# Altium schematic sheet MIDI.SchDoc
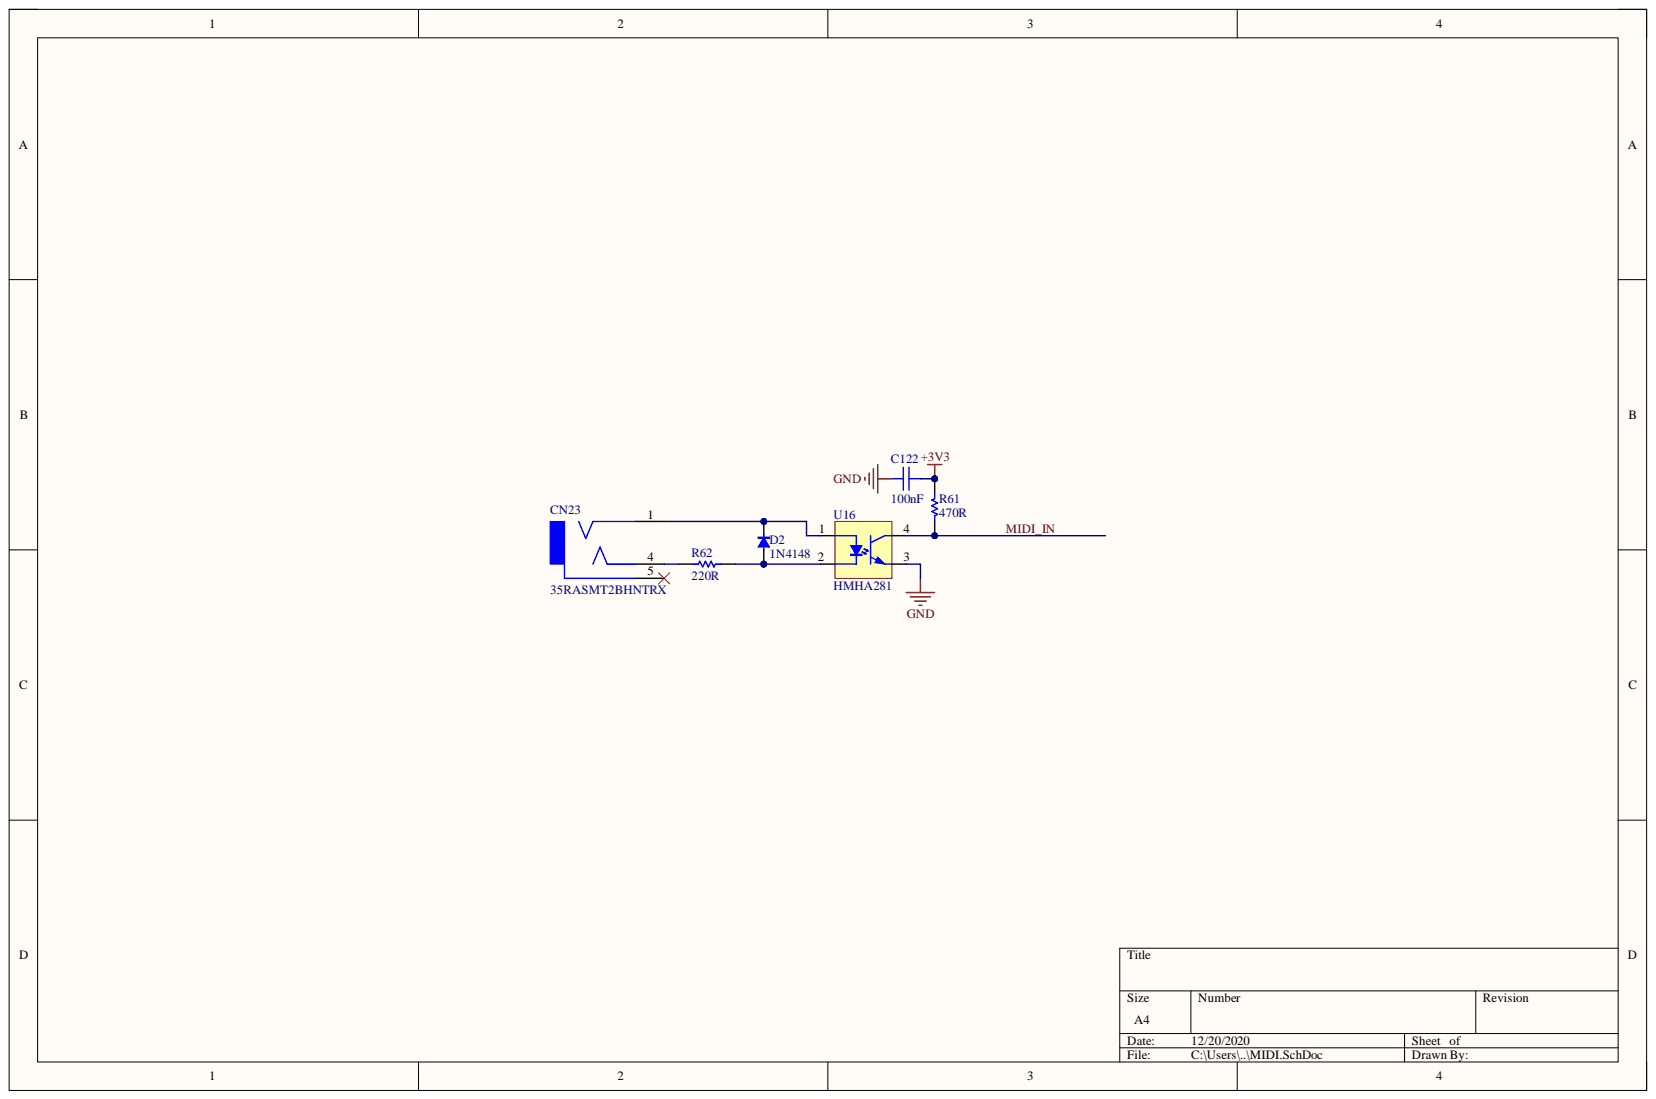
[diagram p0: MIDI.SchDoc]

## Parts
C122  100nF  [AA-0402]
CN23  35RASMT2BHNTRX  [35RASMT2BHNTRX]
D2  1N4148  [SOD-323]
R61  470R  [AA-0402]
R62  220R  [AA-0402]
U16  HMHA281  [OPTO-SMD-4-HMHA281]

## Nets
+3V3: C122.1 R61.2
GND: C122.2 U16.3
MIDI_IN: R61.1 U16.4
NetCN23_1: CN23.1 D2.2 U16.1
NetCN23_4: CN23.4 R62.2
NetD2_1: D2.1 R62.1 U16.2

Not connected: CN23 5

## Library data of the parts
C122 = 00005453: Database Table Name: Capacitor; Component Kind: Standard; Library Name: ALT_DB.DBLIB
D2 = DIODE_T: Component Kind: Standard; Library Name: ULTIMA_LIB.SCHLIB
R61 = 10012142: Database Table Name: Resistor; Component Kind: Standard; Library Name: ALT_DB.DBLIB; Value: 470R
R62 = 10012076Wa: Database Table Name: Resistor; Component Kind: Standard; Library Name: ALT_DB.DBLIB; Signal Integrity: Res; Simulation: RESISTOR
CN23 = 35RASMT2BHNTRX: Component Kind: Standard; Library Name: ULTIMA_LIB.SCHLIB
U16 = HMHA281: Component Kind: Standard; Library Name: ULTIMA_LIB.SCHLIB
